# Altium schematic sheet POWER&UART.SchDoc
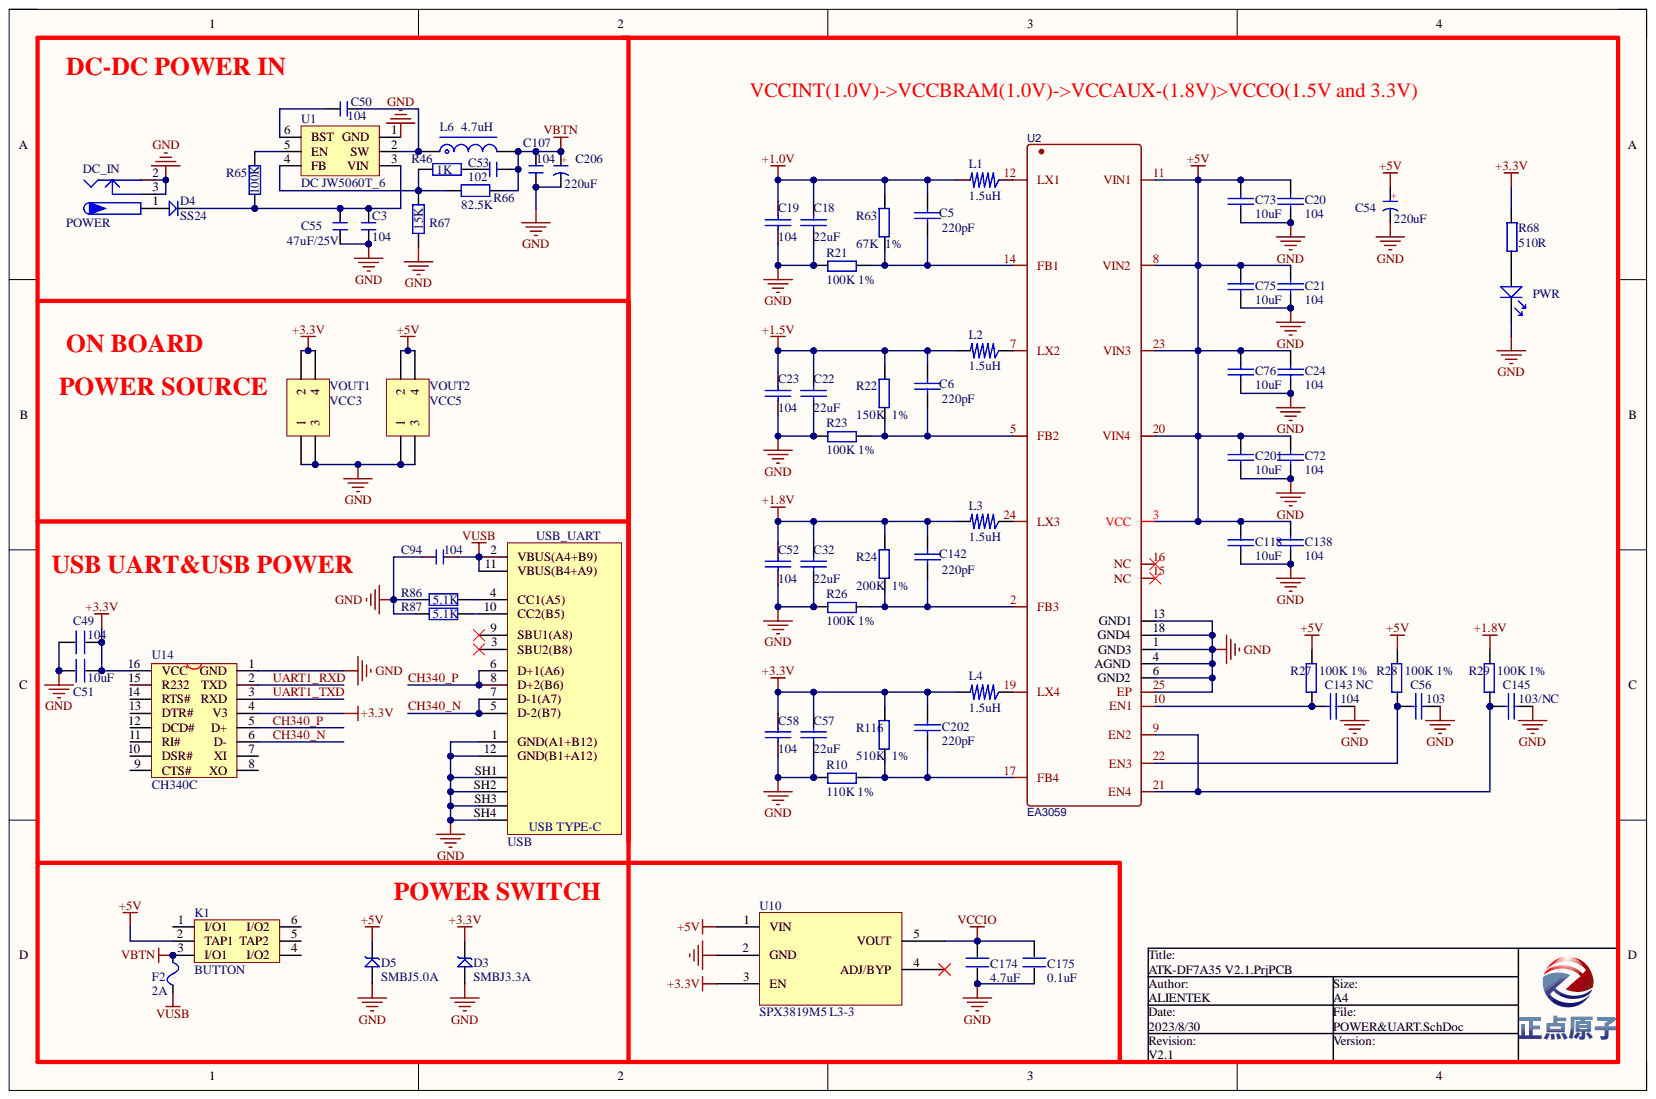
[diagram p0: POWER&UART.SchDoc]

## Parts
C3  104  [0603P]
C5  220pF  [0603P]
C6  220pF  [0603P]
C18  22uF  [0603P]
C19  104  [0603P]
C20  104  [0603P]
C21  104  [0603P]
C22  22uF  [0603P]
C23  104  [0603P]
C24  104  [0603P]
C32  22uF  [0603P]
C49  104  [0603P]
C50  104  [0603P]
C51  10uF  [0603P]
C52  104  [0603P]
C53  102  [0603P]
C54  220uF  [CM D(6.3*5.4)]
C55  47uF/25V  [C1206]
C56  103  [0603P]
C57  22uF  [0603P]
C58  104  [0603P]
C72  104  [0603P]
C73  10uF  [0603P]
C75  10uF  [0603P]
C76  10uF  [0603P]
C94  104  [0603P]
C107  104  [0603P]
C118  10uF  [0603P]
C138  104  [0603P]
C142  220pF  [0603P]
C143_NC  104  [0603P]
C145  103/NC  [0603P]
C174  4.7uF  [0603P]
C175  0.1uF  [0402]
C201  10uF  [0603P]
C202  220pF  [0603P]
C206  220uF  [CM D(6.3*5.4)]
D3  SMBJ3.3A  [smbj]
D4  SS24  [SMA]
D5  SMBJ5.0A  [smbj]
DC_IN  POWER  [DC10B]
F2  2A  [FU 1206]
K1  BUTTON  [BTN8.5*8.5]
L1  1.5uH  [L4X4]
L2  1.5uH  [L4X4]
L3  1.5uH  [L4X4]
L4  1.5uH  [L4X4]
L6  4.7uH  [L-SMD-0420]
PWR  PWR  [SMDLED-0805]
R10  110K 1%  [0603P]
R21  100K 1%  [0603P]
R22  150K  1%  [0603P]
R23  100K 1%  [0603P]
R24  200K  1%  [0603P]
R26  100K 1%  [0603P]
R27  100K 1%  [0603P]
R28  100K 1%  [0603P]
R29  100K 1%  [0603P]
R46  1K  [0603P]
R63  67K  1%  [0603P]
R65  100K  [0603P]
R66  82.5K  [R 0603]
R67  15K  [0603P]
R68  510R  [0603P]
R86  5.1K  [0402]
R87  5.1K  [0402]
R116  510K  1%  [0603P]
U1  DC JW5060T_6  [SOT23-6N]
U2  EA3059  [QFN24]
U10  SPX3819M5 L3-3  [SOT23-5]
U14  CH340C  [SOP16M]
USB_UART  USB  [USB TYPE-C - 10*9]
VOUT1  VCC3  [HDR2X2]
VOUT2  VCC5  [HDR2X2]

## Nets
+1.0V: C5.2 C18.2 C19.2 L1.2 R63.1
+1.5V: C6.2 C22.2 C23.2 L2.2 R22.1
+1.8V: C32.2 C52.2 C142.2 L3.2 R24.1 R29.1
+3.3V: C49.2 C51.1 C57.2 C58.2 C202.2 D3.2 L4.2 R68.2 R116.1 U10.3(EN) U14.4(V3) U14.16(VCC) VOUT1.2 VOUT1.4
+5V: C20.1 C21.1 C24.1 C54.1 C72.1 C73.1 C75.1 C76.1 C118.1 C138.1 C201.1 D5.2 K1.2(TAP1) R27.1 R28.1 U2.3(VCC) U2.8(VIN2) U2.11(VIN1) U2.20(VIN4) U2.23(VIN3) U10.1(VIN) VOUT2.2 VOUT2.4
GND: C3.1 C18.1 C19.1 C20.2 C21.2 C22.1 C23.1 C24.2 C32.1 C49.1 C51.2 C52.1 C54.2 C55.1 C56.1 C57.1 C58.1 C72.2 C73.2 C75.2 C76.2 C94.1 C107.2 C118.2 C138.2 C143_NC.2 C145.1 C174.2 C175.2 C201.2 C206.2 D3.1 D5.1 DC_IN.2 DC_IN.3 PWR.2 R10.1 R21.1 R23.1 R26.1 R67.2 R86.1 R87.1 U1.1(GND) U2.1(GND3) U2.4(AGND) U2.6(GND2) U2.13(GND1) U2.18(GND4) U2.25(EP) U10.2(GND) U14.1(GND) USB_UART.1(GND(A1+B12)) USB_UART.12(GND(B1+A12)) USB_UART.SH1(Shield1) USB_UART.SH2(Shield2) USB_UART.SH3(Shield3) USB_UART.SH4(Shield4) VOUT1.1 VOUT1.3 VOUT2.1 VOUT2.3
VBTN: C53.2 C107.1 C206.1 F2.2 K1.3(I/O1) L6.2 R66.2
VCCIO: C174.1 C175.1 U10.5(VOUT)
VUSB: C94.2 F2.1 USB_UART.2(VBUS(A4+B9)) USB_UART.11(VBUS(B4+A9))
CH340_N: U14.6(D-) USB_UART.5(D-2(B7)) USB_UART.7(D-1(A7))
CH340_P: U14.5(D+) USB_UART.6(D+1(A6)) USB_UART.8(D+2(B6))
UART1_RXD: U14.2(TXD)
UART1_TXD: U14.3(RXD)
NetC3_2: C3.2 C55.2 D4.2 R65.2 U1.3(VIN)
NetC5_1: C5.1 R21.2 R63.2 U2.14(FB1)
NetC6_1: C6.1 R22.2 R23.2 U2.5(FB2)
NetC50_1: C50.1 U1.6(BST)
NetC50_2: C50.2 L6.1 U1.2(SW)
NetC53_1: C53.1 R46.2
NetC56_2: C56.2 R28.2 U2.22(EN3)
NetC142_1: C142.1 R24.2 R26.2 U2.2(FB3)
NetC143 NC_1: C143_NC.1 R27.2 U2.10(EN1)
NetC145_2: C145.2 R29.2 U2.9(EN2) U2.21(EN4)
NetC202_1: C202.1 R10.2 R116.2 U2.17(FB4)
NetD4_1: D4.1 DC_IN.1
NetL1_1: L1.1 U2.12(LX1)
NetL2_1: L2.1 U2.7(LX2)
NetL3_1: L3.1 U2.24(LX3)
NetL4_1: L4.1 U2.19(LX4)
NetPWR_1: PWR.1 R68.1
NetR46_1: R46.1 R66.1 R67.1 U1.4(FB)
NetR65_1: R65.1 U1.5(EN)
NetR86_2: R86.2 USB_UART.4(CC1(A5))
NetR87_2: R87.2 USB_UART.10(CC2(B5))

Not connected: K1 1(I/O1) 4(I/O2) 5(TAP2) 6(I/O2); U2 15(NC) 16(NC); U10 4(ADJ/BYP); U14 7(XI) 8(XO) 9(CTS#) 10(DSR#) 11(RI#) 12(DCD#) 13(DTR#) 14(RTS#) 15(R232); USB_UART 3(SBU2(B8)) 9(SBU1(A8))

## Library data of the parts
C3 C50 C53 C55 C94 C107 = C: 无极性贴片电容; 版权归属: 源创客; BOM名称: 无极性贴片电容; Component Kind: Standard; Library Name: 电阻-电容-电感.SchLib; 学习资源: www.ooocke.com
C5 C6 C18-C24 C32 C52 C56-C58 C72 C73 C75 C76 C118 C138 C142 C143_NC C145 C201 C202 = CAP: Capacitor; Component Kind: Standard
C49 C51 C174 C175 = C: Component Kind: Standard; Library Name: MYCOMLIB.IntLib
C54 C206 = C-CM: 贴片电解电容; 版权归属: 源创客; BOM名称: 贴片电解电容; Component Kind: Standard; Library Name: 电阻-电容-电感.SchLib; 学习资源: www.ooocke.com
D3 D5 = SMBJ: TVS; Component Kind: Standard; Library Name: ALIENTEK_COMPONENT.IntLib
D4 = SS14: 肖特基二极管; 属性: 40V/1A; 版权归属: 源创客; BOM名称: 肖特基二极管; Component Kind: Standard; Library Name: 二极管-整流桥.SchLib; 学习资源: www.ooocke.com
DC_IN = P-DC: 低压电源接口; BOM名称: DC电源接口; 版权归属: 源创客; Component Kind: Standard; Library Name: 接口类.SchLib; 学习资源: www.ooocke.com
F2 = FU: 贴片保险丝; 版权归属: 源创客; BOM名称: 贴片保险丝; Component Kind: Standard; Library Name: IMX6UL_ALPHA_V1.0.IntLib; 学习资源: www.ooocke.com
L1-L4 = L_SOP: Component Kind: Standard; Library Name: ALIENTEK_COMPONENT.IntLib
L6 = L-CDRH: 功率屏蔽电感; 版权归属: 源创客; BOM名称: 功率屏蔽电感; Component Kind: Standard; Library Name: 电阻-电容-电感.SchLib; 学习资源: www.ooocke.com
PWR = LED: Component Kind: Standard; Library Name: ALIENTEK_COMPONENT.IntLib
R10 R21-R24 R26-R29 R63 R68 R116 = R: Component Kind: Standard
  R10 R21-R24 R26-R29 R63 R116: Library Name: ALIENTEK_COMPONENT.IntLib
  R68: Library Name: MYCOMLIB.IntLib
R46 R65-R67 R86 R87 = R: 贴片电阻; 版权归属: 源创客; BOM名称: 贴片电阻; Component Kind: Standard; Library Name: 电阻-电容-电感.SchLib; 学习资源: www.ooocke.com
U1 = DC JW5060T_6: DC降压芯片; Component Kind: Standard; Library Name: LDO和DCDC.SchLib
U2 = EA3059: BOM_Manufacturer: Everanalog; SMT Type: Extend; BOM_LCSC Assembly: Yes; BOM_Manufacturer Part: EA3059; BOM_Supplier: LCSC; BOM_Supplier Part: C111586; Component Kind: Standard; spicePre: U; spiceSymbolName: EA3059; Library Name: NewStart.SCHLIB
U10 = SP3819M5: Component Kind: Standard; Library Name: Storm_IV_4CEXX_V1.2.SchLib
U14 = CH340G: USB2UART; Component Kind: Standard; Library Name: ALIENTEK_COMPONENT.IntLib
USB_UART = USB Type-C: 12P TypeC 母座; Component Kind: Standard; Library Name: Lycreturn.IntLib
VOUT1 VOUT2 = Header 2X2: Header, 3-Pin, Dual row; Component Kind: Standard; LatestRevisionDate: 17-Jul-2002; LatestRevisionNote: Re-released for DXP Platform.; Library Name: A7_Mini.SCHLIB; Publisher: Altium Limited
K1 = BUTTON: Component Kind: Standard; Library Name: ALIENTEK_COMPONENT.IntLib
